# Altium schematic sheet Schematic.SchDoc(Schematic)
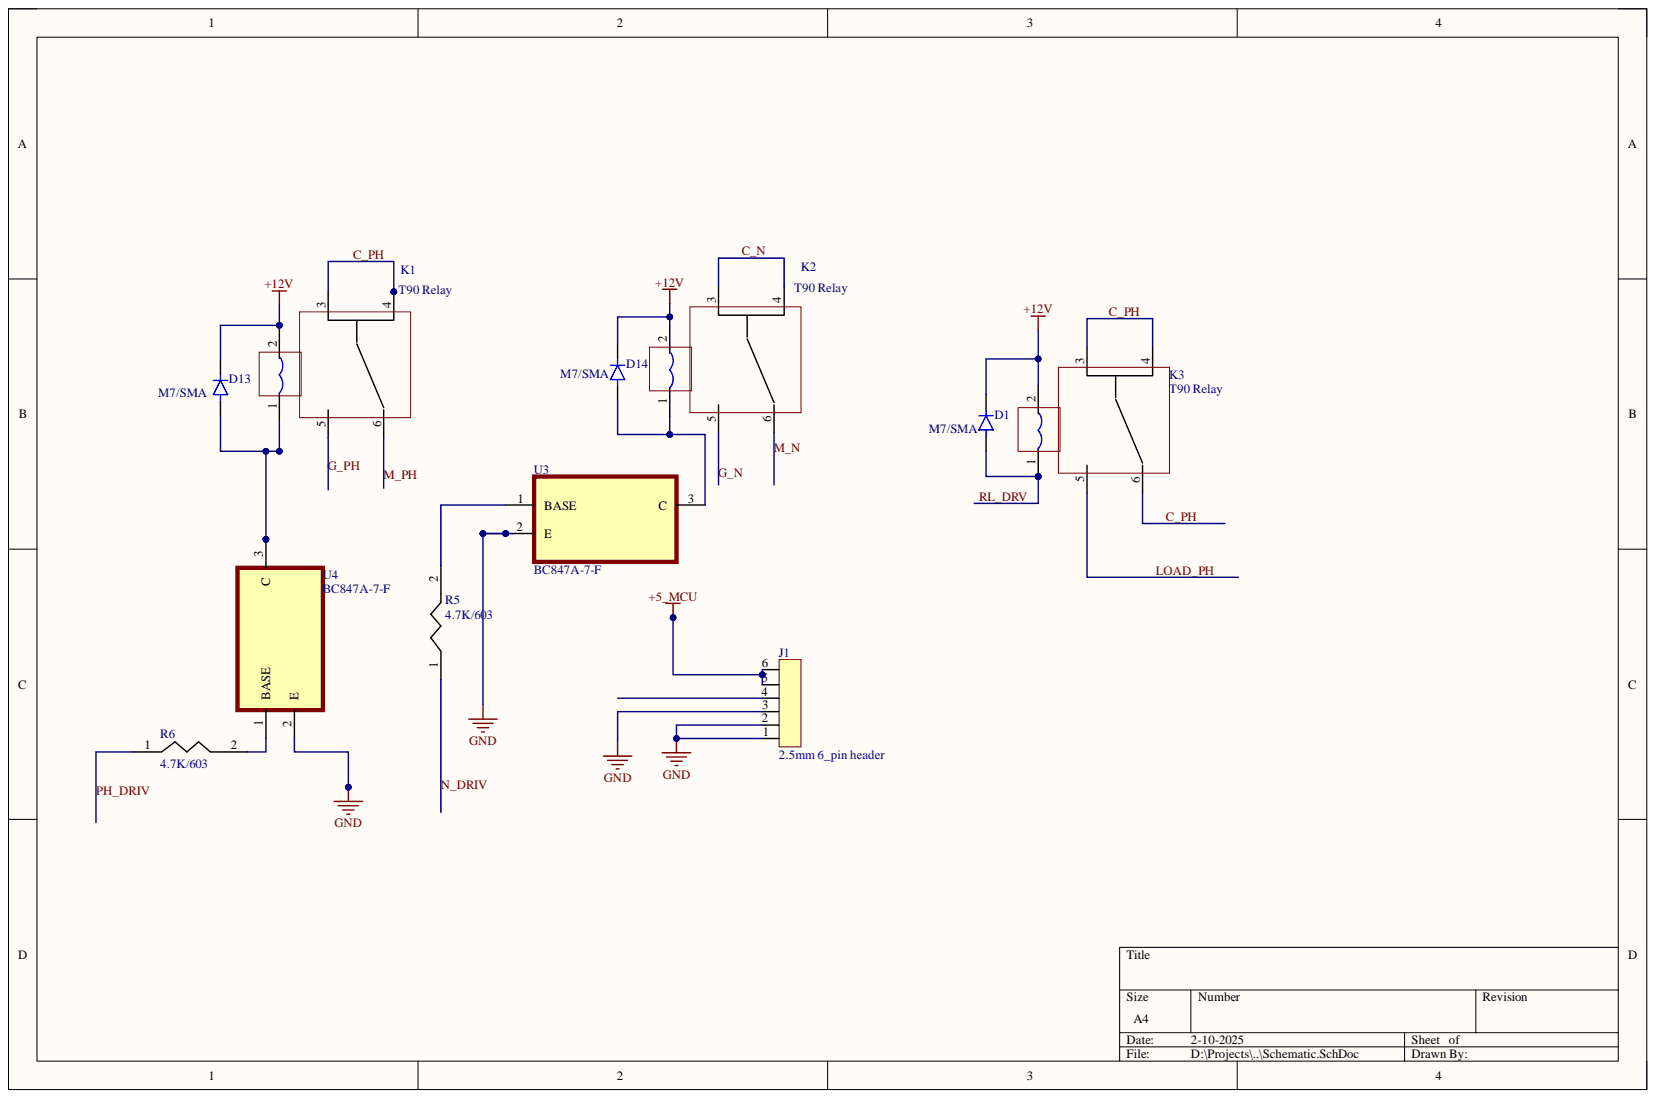
[diagram p0: Schematic.SchDoc]

## Parts
D1  M7/SMA  [DIO_LL4148]
D13  M7/SMA  [DIO_LL4148]
D14  M7/SMA  [DIO_LL4148]
J1  2.5mm 6_pin header
K1  T90 Relay  [T90 Relay]
K2  T90 Relay  [T90 Relay]
K3  T90 Relay  [T90 Relay]
R5  4.7K/603  [603]
R6  4.7K/603  [603]
U3  BC847A-7-F  [SOT95P240X110-3N]
U4  BC847A-7-F  [SOT95P240X110-3N]

## Nets
+5_MCU: J1.5 J1.6
+12V: D1.C D13.C D14.C K1.2 K2.2 K3.2
GND: J1.1 J1.2 J1.3 U3.2 U4.2
C_N: K2.3 K2.4
C_PH: K1.3 K1.4 K3.3 K3.4 K3.6
G_N: K2.5
G_PH: K1.5
LOAD_PH: K3.5
M_N: K2.6
M_PH: K1.6
N_DRIV: R5.1
PH_DRIV: R6.1
RL_DRV: D1.A K3.1
NetD13_A: D13.A K1.1 U4.3
NetD14_A: D14.A K2.1 U3.3
NetR5_2: R5.2 U3.1
NetR6_2: R6.2 U4.1

Not connected: J1 4

## Library data of the parts
D1 D13 D14 = LL4148: Diode Small Signal Switching 100V 0.15A Automotive 2-Pin Mini-MELF T/R; MF: Diotec Semiconductor; Availability: In Stock; Check_prices: https://www.snapeda.com/parts/LL4148/Diotec/view-part/?ref=eda; Component Kind: Standard; Library Name: LL4148.IntLib; MP: LL4148; Package: MINIMELF-2 Diotec; Price: None; Purchase-URL: https://pricing.snapeda.com/search/part/LL4148/?ref=eda; SnapEDA_Link: https://www.snapeda.com/parts/LL4148/Diotec/view-part/?ref=snap
J1 = 6_pin 2.5mm Header: Component Kind: Standard; Library Name: Custom1.IntLib
R5 R6 = 603 Resistor: Component Kind: Standard; Library Name: Custom1.IntLib
U3 U4 = BC847A-7-F: Bipolar (BJT) Transistor NPN 45V 100mA 300MHz 300mW Surface Mount SOT-23-3; MF: Diodes Inc.; Availability: Good; Check_prices: https://www.snapeda.com/parts/BC847A-7-F/Diodes%20Inc./view-part/484082/?ref=eda; Component Kind: Standard; Library Name: BC847A-7-F.IntLib; MP: BC847A-7-F; Package: SOT-23-3 Diodes Inc.; Price: None; Purchase-URL: https://pricing.snapeda.com/search/part/BC847A-7-F/?ref=eda; SnapEDA_Link: https://www.snapeda.com/parts/BC847A-7-F/Diodes%20Inc./view-part/484082/?ref=snap
K1-K3 = T90 Relay: Component Kind: Standard; Library Name: Custom1.IntLib
